# Altium schematic sheet High Voltage Digital Pots Rev 5.SchDoc("High Voltage Digital Pots Rev 5")
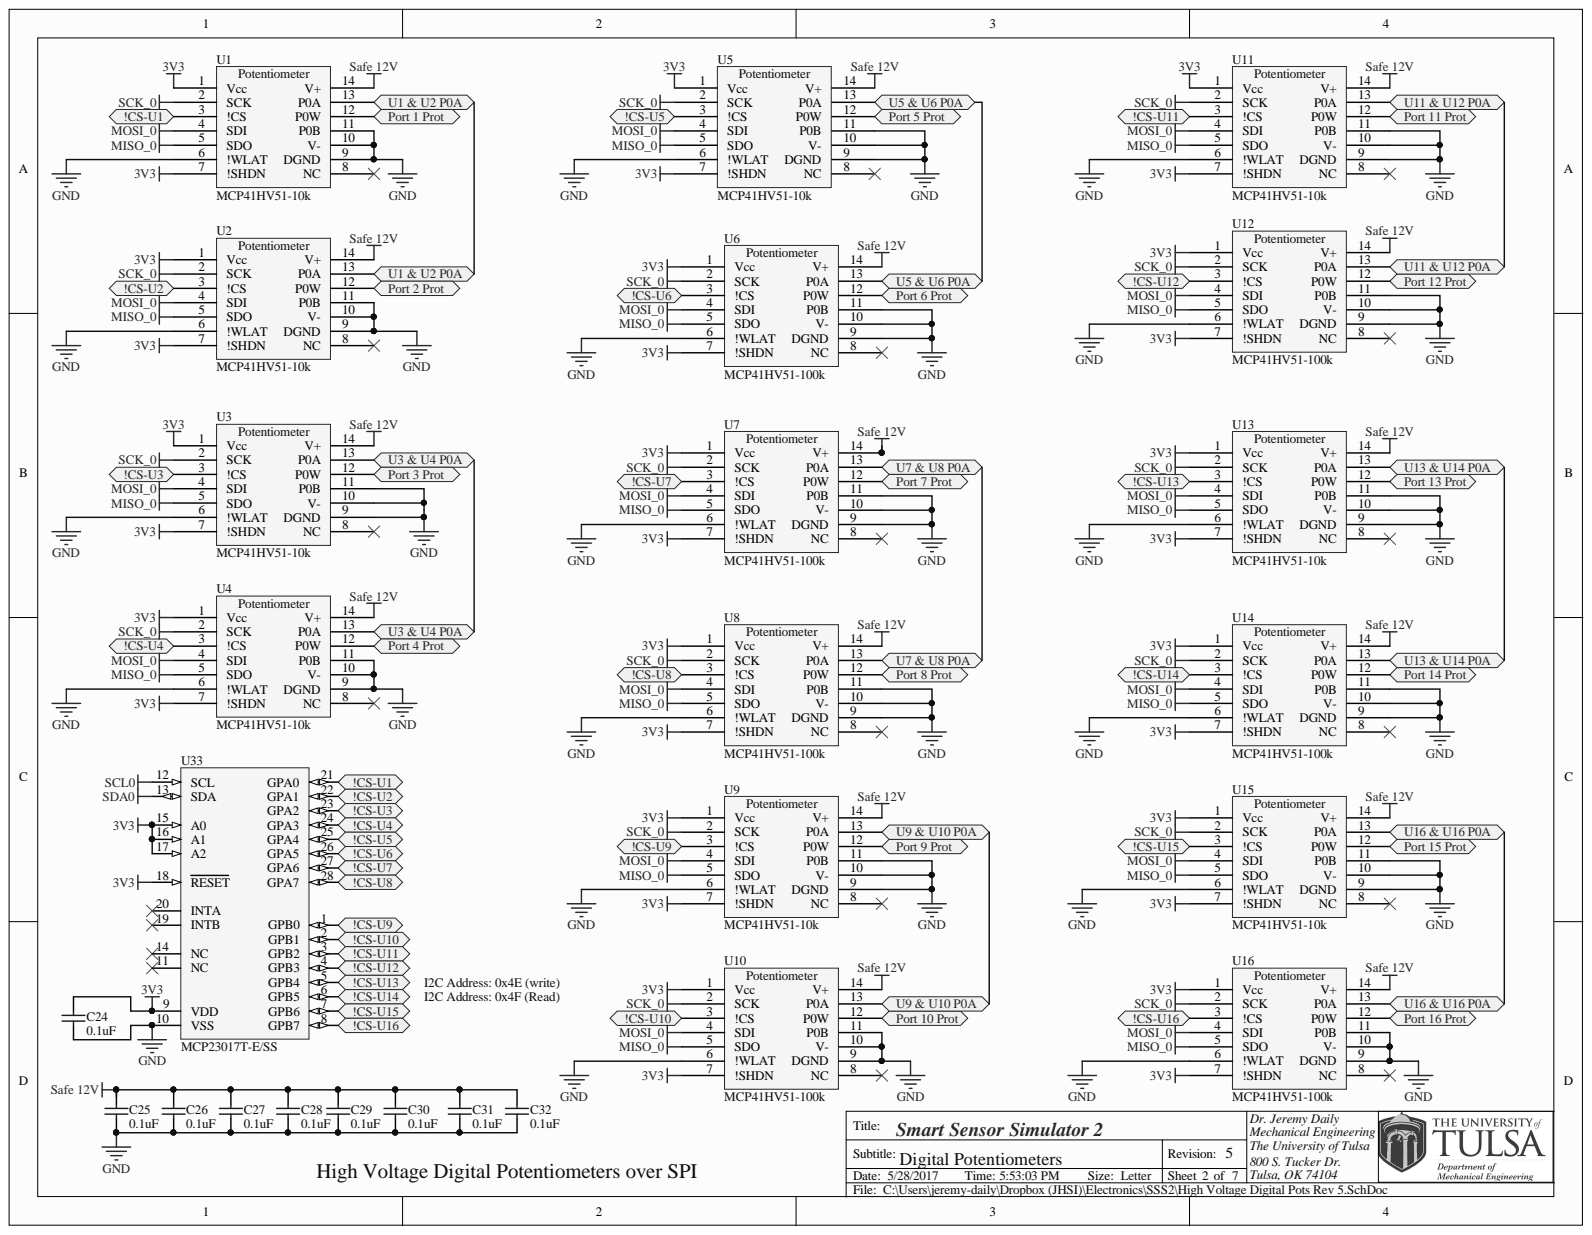
[diagram p0: High Voltage Digital Pots Rev 5.SchDoc]

## Parts
C24  0.1uF  [0603]
C25  0.1uF  [0603]
C26  0.1uF  [0603]
C27  0.1uF  [0603]
C28  0.1uF  [0603]
C29  0.1uF  [0603]
C30  0.1uF  [0603]
C31  0.1uF  [0603]
C32  0.1uF  [0603]
U1  MCP41HV51-10k  [TSSOP-ST14_M]
U2  MCP41HV51-10k  [TSSOP-ST14_M]
U3  MCP41HV51-10k  [TSSOP-ST14_M]
U4  MCP41HV51-10k  [TSSOP-ST14_M]
U5  MCP41HV51-10k  [TSSOP-ST14_M]
U6  MCP41HV51-100k  [TSSOP-ST14_M]
U7  MCP41HV51-100k  [TSSOP-ST14_M]
U8  MCP41HV51-100k  [TSSOP-ST14_M]
U9  MCP41HV51-10k  [TSSOP-ST14_M]
U10  MCP41HV51-100k  [TSSOP-ST14_M]
U11  MCP41HV51-10k  [TSSOP-ST14_M]
U12  MCP41HV51-100k  [TSSOP-ST14_M]
U13  MCP41HV51-10k  [TSSOP-ST14_M]
U14  MCP41HV51-100k  [TSSOP-ST14_M]
U15  MCP41HV51-10k  [TSSOP-ST14_M]
U16  MCP41HV51-100k  [TSSOP-ST14_M]
U33  MCP23017T-E/SS  [SSOP-SS28_N]

## Ports
!CS-U1, !CS-U2, !CS-U3, !CS-U4, !CS-U5, !CS-U6, !CS-U7, !CS-U8, !CS-U9, !CS-U10, !CS-U11, !CS-U12, !CS-U13, !CS-U14, !CS-U15, !CS-U16, PORT 1 PROT, PORT 2 PROT, PORT 3 PROT, PORT 4 PROT, PORT 5 PROT, PORT 6 PROT, PORT 7 PROT, PORT 8 PROT, PORT 9 PROT, PORT 10 PROT, PORT 11 PROT, PORT 12 PROT, PORT 13 PROT, PORT 14 PROT, PORT 15 PROT, PORT 16 PROT, U1 & U2 P0A, U3 & U4 P0A, U5 & U6 P0A, U7 & U8 P0A, U9 & U10 P0A, U11 & U12 P0A, U13 & U14 P0A, U16 & U16 P0A

## Nets
3V3: C24.2 U1.1(Vcc) U1.7(!SHDN) U2.1(Vcc) U2.7(!SHDN) U3.1(Vcc) U3.7(!SHDN) U4.1(Vcc) U4.7(!SHDN) U5.1(Vcc) U5.7(!SHDN) U6.1(Vcc) U6.7(!SHDN) U7.1(Vcc) U7.7(!SHDN) U8.1(Vcc) U8.7(!SHDN) U9.1(Vcc) U9.7(!SHDN) U10.1(Vcc) U10.7(!SHDN) U11.1(Vcc) U11.7(!SHDN) U12.1(Vcc) U12.7(!SHDN) U13.1(Vcc) U13.7(!SHDN) U14.1(Vcc) U14.7(!SHDN) U15.1(Vcc) U15.7(!SHDN) U16.1(Vcc) U16.7(!SHDN) U33.9(VDD) U33.15(A0) U33.16(A1) U33.17(A2) U33.18(RESET)
GND: C24.1 C25.1 C26.1 C27.1 C28.1 C29.1 C30.1 C31.1 C32.1 U1.6(!WLAT) U1.9(DGND) U1.10(V-) U1.11(P0B) U2.6(!WLAT) U2.9(DGND) U2.10(V-) U2.11(P0B) U3.6(!WLAT) U3.9(DGND) U3.10(V-) U3.11(P0B) U4.6(!WLAT) U4.9(DGND) U4.10(V-) U4.11(P0B) U5.6(!WLAT) U5.9(DGND) U5.10(V-) U5.11(P0B) U6.6(!WLAT) U6.9(DGND) U6.10(V-) U6.11(P0B) U7.6(!WLAT) U7.9(DGND) U7.10(V-) U7.11(P0B) U8.6(!WLAT) U8.9(DGND) U8.10(V-) U8.11(P0B) U9.6(!WLAT) U9.9(DGND) U9.10(V-) U9.11(P0B) U10.6(!WLAT) U10.9(DGND) U10.10(V-) U10.11(P0B) U11.6(!WLAT) U11.9(DGND) U11.10(V-) U11.11(P0B) U12.6(!WLAT) U12.9(DGND) U12.10(V-) U12.11(P0B) U13.6(!WLAT) U13.9(DGND) U13.10(V-) U13.11(P0B) U14.6(!WLAT) U14.9(DGND) U14.10(V-) U14.11(P0B) U15.6(!WLAT) U15.9(DGND) U15.10(V-) U15.11(P0B) U16.6(!WLAT) U16.9(DGND) U16.10(V-) U16.11(P0B) U33.10(VSS)
MISO_0: U1.5(SDO) U2.5(SDO) U3.5(SDO) U4.5(SDO) U5.5(SDO) U6.5(SDO) U7.5(SDO) U8.5(SDO) U9.5(SDO) U10.5(SDO) U11.5(SDO) U12.5(SDO) U13.5(SDO) U14.5(SDO) U15.5(SDO) U16.5(SDO)
MOSI_0: U1.4(SDI) U2.4(SDI) U3.4(SDI) U4.4(SDI) U5.4(SDI) U6.4(SDI) U7.4(SDI) U8.4(SDI) U9.4(SDI) U10.4(SDI) U11.4(SDI) U12.4(SDI) U13.4(SDI) U14.4(SDI) U15.4(SDI) U16.4(SDI)
SAFE 12V: C25.2 C26.2 C27.2 C28.2 C29.2 C30.2 C31.2 C32.2 U1.14(V+) U2.14(V+) U3.14(V+) U4.14(V+) U5.14(V+) U6.14(V+) U7.14(V+) U8.14(V+) U9.14(V+) U10.14(V+) U11.14(V+) U12.14(V+) U13.14(V+) U14.14(V+) U15.14(V+) U16.14(V+)
SCK_0: U1.2(SCK) U2.2(SCK) U3.2(SCK) U4.2(SCK) U5.2(SCK) U6.2(SCK) U7.2(SCK) U8.2(SCK) U9.2(SCK) U10.2(SCK) U11.2(SCK) U12.2(SCK) U13.2(SCK) U14.2(SCK) U15.2(SCK) U16.2(SCK)
SCL0: U33.12(SCL)
SDA0: U33.13(SDA)
!CS-U1: U1.3(!CS) U33.21(GPA0) ; port !CS-U1
!CS-U2: U2.3(!CS) U33.22(GPA1) ; port !CS-U2
!CS-U3: U3.3(!CS) U33.23(GPA2) ; port !CS-U3
!CS-U4: U4.3(!CS) U33.24(GPA3) ; port !CS-U4
!CS-U5: U5.3(!CS) U33.25(GPA4) ; port !CS-U5
!CS-U6: U6.3(!CS) U33.26(GPA5) ; port !CS-U6
!CS-U7: U7.3(!CS) U33.27(GPA6) ; port !CS-U7
!CS-U8: U8.3(!CS) U33.28(GPA7) ; port !CS-U8
!CS-U9: U9.3(!CS) U33.1(GPB0) ; port !CS-U9
!CS-U10: U10.3(!CS) U33.2(GPB1) ; port !CS-U10
!CS-U11: U11.3(!CS) U33.3(GPB2) ; port !CS-U11
!CS-U12: U12.3(!CS) U33.4(GPB3) ; port !CS-U12
!CS-U13: U13.3(!CS) U33.5(GPB4) ; port !CS-U13
!CS-U14: U14.3(!CS) U33.6(GPB5) ; port !CS-U14
!CS-U15: U15.3(!CS) U33.7(GPB6) ; port !CS-U15
!CS-U16: U16.3(!CS) U33.8(GPB7) ; port !CS-U16
U1 & U2 P0A: U1.13(P0A) U2.13(P0A) ; port U1 & U2 P0A
U3 & U4 P0A: U3.13(P0A) U4.13(P0A) ; port U3 & U4 P0A
U5 & U6 P0A: U5.13(P0A) U6.13(P0A) ; port U5 & U6 P0A
U7 & U8 P0A: U7.13(P0A) U8.13(P0A) ; port U7 & U8 P0A
U9 & U10 P0A: U9.13(P0A) U10.13(P0A) ; port U9 & U10 P0A
U11 & U12 P0A: U11.13(P0A) U12.13(P0A) ; port U11 & U12 P0A
U13 & U14 P0A: U13.13(P0A) U14.13(P0A) ; port U13 & U14 P0A
U16 & U16 P0A: U15.13(P0A) U16.13(P0A) ; port U16 & U16 P0A
NetU1_12: U1.12(P0W) ; port PORT 1 PROT
NetU2_12: U2.12(P0W) ; port PORT 2 PROT
NetU3_12: U3.12(P0W) ; port PORT 3 PROT
NetU4_12: U4.12(P0W) ; port PORT 4 PROT
NetU5_12: U5.12(P0W) ; port PORT 5 PROT
NetU6_12: U6.12(P0W) ; port PORT 6 PROT
NetU7_12: U7.12(P0W) ; port PORT 7 PROT
NetU8_12: U8.12(P0W) ; port PORT 8 PROT
NetU9_12: U9.12(P0W) ; port PORT 9 PROT
NetU10_12: U10.12(P0W) ; port PORT 10 PROT
NetU11_12: U11.12(P0W) ; port PORT 11 PROT
NetU12_12: U12.12(P0W) ; port PORT 12 PROT
NetU13_12: U13.12(P0W) ; port PORT 13 PROT
NetU14_12: U14.12(P0W) ; port PORT 14 PROT
NetU15_12: U15.12(P0W) ; port PORT 15 PROT
NetU16_12: U16.12(P0W) ; port PORT 16 PROT

Not connected: U1 8(NC); U2 8(NC); U3 8(NC); U4 8(NC); U5 8(NC); U6 8(NC); U7 8(NC); U8 8(NC); U9 8(NC); U10 8(NC); U11 8(NC); U12 8(NC); U13 8(NC); U14 8(NC); U15 8(NC); U16 8(NC); U33 11(NC) 14(NC) 19(INTB) 20(INTA)

## Library data of the parts
C24-C32 = Cap: CAP CER 0.1UF 50V 10% X7R 0603; Manufacturer: Murata Electronics North America; PartNumber: GRM188R71H104KA93D; Component Kind: Standard; Library Name: SSS Schematic Library.SchLib; Signal Integrity: Cap; Simulation: CAP; Supplier 1: Digi-Key; Supplier Part Number 1: 490-1519-1-ND
U1-U5 U9 U11 U13 U15 = MCP41HVX1: 7/8-Bit Single, +36V (±18V) Digital POT  with SPI Serial Interface and Volatile Memory; Manufacturer: Microchip Technology; PartNumber: MCP41HV51-103E/ST; Component Kind: Standard; Library Name: SSS Integrated Library.IntLib; Supplier 1: Digi-Key; Supplier Part Number 1: MCP41HV51-103E/ST-ND
U6 U7 = MCP41HVX1: 7/8-Bit Single, +36V (±18V) Digital POT  with SPI Serial Interface and Volatile Memory; Manufacturer: Microchip Technology; PartNumber: MCP41HV51-104E/ST; Component Kind: Standard; Library Name: SSS Integrated Library.IntLib; Supplier 1: Digi-Key; Supplier Part Number 1: MCP41HV51-104E/ST-ND
U8 U10 U12 U14 U16 = MCP41HVX1: Digital Potentiometer 100k Ohm 1 Circuit 256 Taps SPI Interface 14-TSSOP; Manufacturer: Microchip Technology; PartNumber: MCP41HV51-104E/ST; Component Kind: Standard; Library Name: SSS Integrated Library.IntLib; Supplier 1: Digi-Key; Supplier Part Number 1: MCP41HV51-104E/ST-ND
U33 = MCP23017T-E/SS: 16-Bit I/O Expander with Serial Interface, 28-Pin SSOP, Extended Temperature, Tape and Reel; Manufacturer: Microchip; Component Kind: Standard; Library Name: Microchip Interface.IntLib; PartNumber: MCP23017T-E/SS; Supplier 1: Digi-Key; Supplier Part Number 1: MCP23017T-E/SSCT-ND
